# Altium schematic sheet FPGA_MISC.SchDoc(FPGA_MISC)
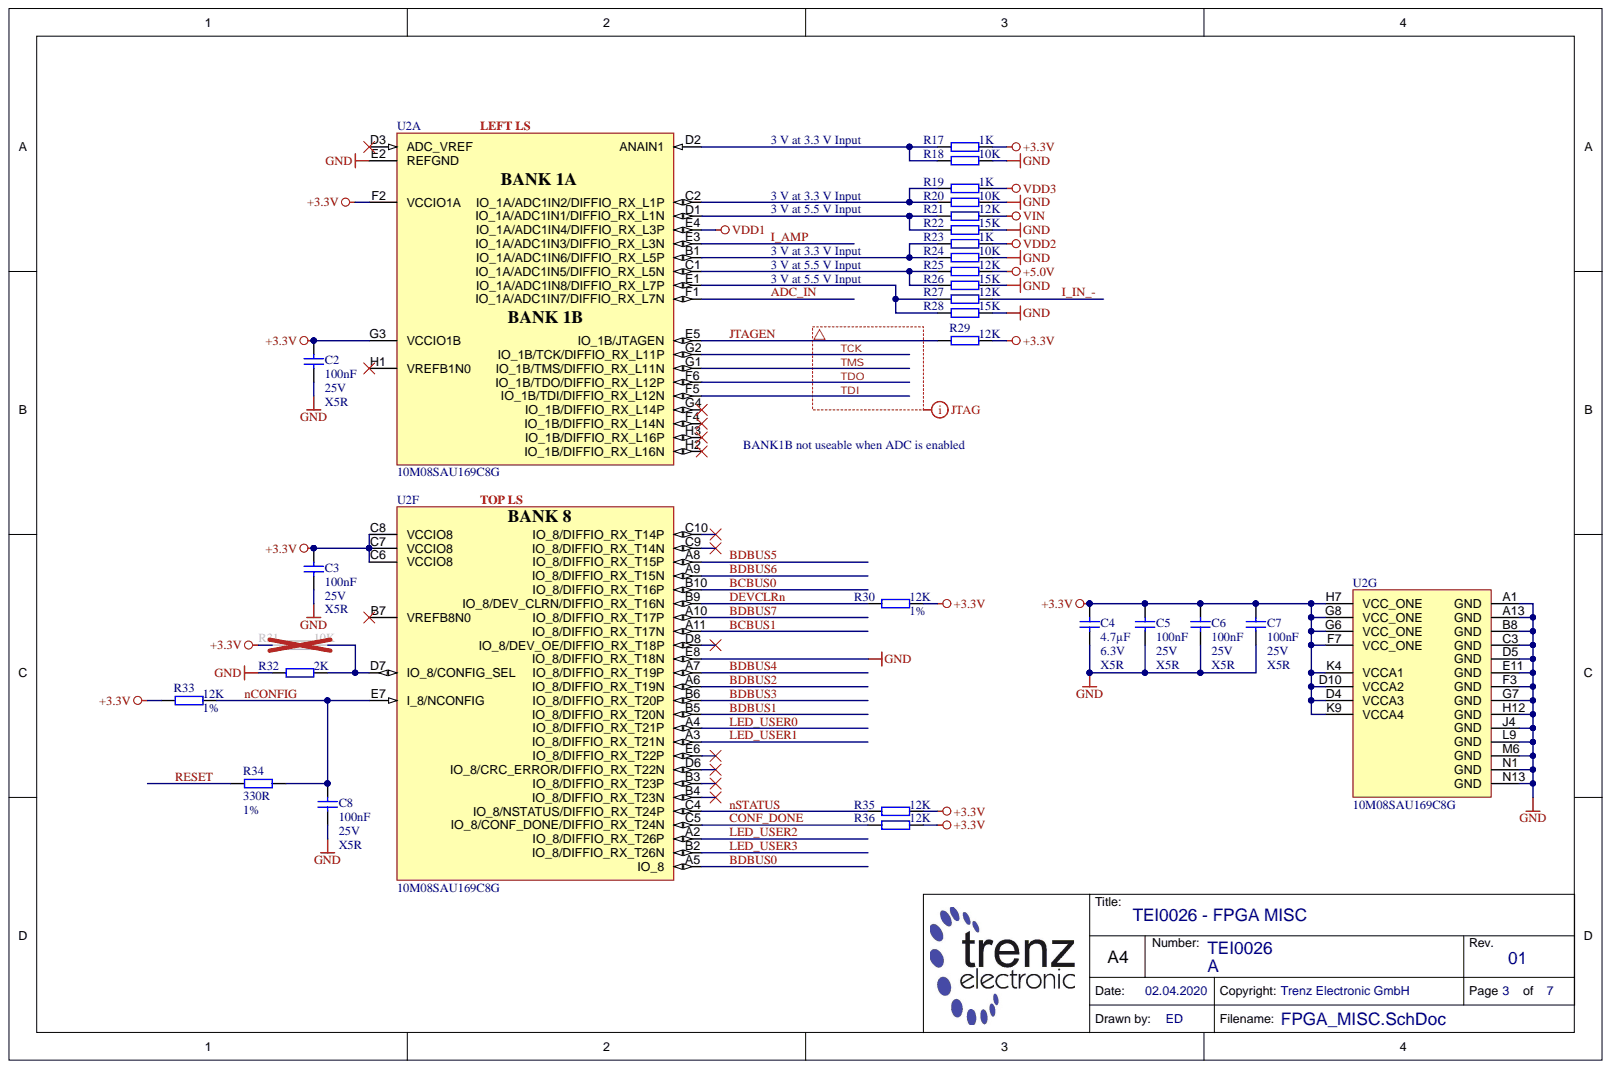
[diagram p0: FPGA_MISC.SchDoc]

## Parts
C2  100nF  Voltage: 25V  Technology: X5R  [CAPC1005X55N]
C3  100nF  Voltage: 25V  Technology: X5R  [CAPC1005X55N]
C4  4.7µF  Voltage: 6.3V  Technology: X5R  [CAPC1005X60N]
C5  100nF  Voltage: 25V  Technology: X5R  [CAPC1005X55N]
C6  100nF  Voltage: 25V  Technology: X5R  [CAPC1005X55N]
C7  100nF  Voltage: 25V  Technology: X5R  [CAPC1005X55N]
C8  100nF  Voltage: 25V  [CAPC1005X55N]
R17  1K  [RESC1005X40N]
R18  10K  [RESC1005X40N]
R19  1K  [RESC1005X40N]
R20  10K  [RESC1005X40N]
R21  12K  [RESC1005X40N]
R22  15K  [RESC1005X40N]
R23  1K  [RESC1005X40N]
R24  10K  [RESC1005X40N]
R25  12K  [RESC1005X40N]
R26  15K  [RESC1005X40N]
R27  12K  [RESC1005X40N]
R28  15K  [RESC1005X40N]
R29  12K  [RESC1005X40N]
R30  12K  Tolerance: 1%  [RESC1005X40N]
R31  10K  [RESC1005X40N]
R32  2K  [RESC1005X40N]
R33  12K  Tolerance: 1%  [RESC1005X40N]
R34  330R  Tolerance: 1%  [RESC1005X40N]
R35  12K  [RESC1005X40N]
R36  12K  [RESC1005X40N]
U2  10M08SAU169C8G  [BGA169C80P13X13_11X11X15]  (parts A,F,G)

## Nets
+3.3V: C2.1 C3.1 C4.1 C5.1 C6.1 C7.1 R17.2 R29.2 R30.2 R31.1 R33.1 R35.2 R36.2 U2.C6(VCCIO8) U2.C7(VCCIO8) U2.C8(VCCIO8) U2.D4(VCCA3) U2.D10(VCCA2) U2.F2(VCCIO1A) U2.F7(VCC_ONE) U2.G3(VCCIO1B) U2.G6(VCC_ONE) U2.G8(VCC_ONE) U2.H7(VCC_ONE) U2.K4(VCCA1) U2.K9(VCCA4)
+5.0V: R25.2
GND: C2.2 C3.2 C4.2 C5.2 C6.2 C7.2 C8.2 R18.2 R20.2 R22.2 R24.2 R26.2 R28.2 R32.2 U2.A1(GND) U2.A13(GND) U2.B8(GND) U2.C3(GND) U2.D5(GND) U2.E2(REFGND) U2.E8(IO_8/DIFFIO_RX_T18N) U2.E11(GND) U2.F3(GND) U2.G7(GND) U2.H12(GND) U2.J4(GND) U2.L9(GND) U2.M6(GND) U2.N1(GND) U2.N13(GND)
VDD1: U2.E4(IO_1A/ADC1IN4/DIFFIO_RX_L3P)
VDD2: R23.2
VDD3: R19.2
VIN: R21.2
ADC_IN: U2.F1(IO_1A/ADC1IN7/DIFFIO_RX_L7N)
BCBUS0: U2.B10(IO_8/DIFFIO_RX_T16P)
BCBUS1: U2.A11(IO_8/DIFFIO_RX_T17N)
BDBUS0: U2.A5(IO_8)
BDBUS1: U2.B5(IO_8/DIFFIO_RX_T20N)
BDBUS2: U2.A6(IO_8/DIFFIO_RX_T19N)
BDBUS3: U2.B6(IO_8/DIFFIO_RX_T20P)
BDBUS4: U2.A7(IO_8/DIFFIO_RX_T19P)
BDBUS5: U2.A8(IO_8/DIFFIO_RX_T15P)
BDBUS6: U2.A9(IO_8/DIFFIO_RX_T15N)
BDBUS7: U2.A10(IO_8/DIFFIO_RX_T17P)
CONF_DONE: R36.1 U2.C5(IO_8/CONF_DONE/DIFFIO_RX_T24N)
DEVCLRn: R30.1 U2.B9(IO_8/DEV_CLRN/DIFFIO_RX_T16N)
I_AMP: U2.E3(IO_1A/ADC1IN3/DIFFIO_RX_L3N)
I_IN_-: R27.2
JTAGEN: R29.1 U2.E5(IO_1B/JTAGEN)
LED_USER0: U2.A4(IO_8/DIFFIO_RX_T21P)
LED_USER1: U2.A3(IO_8/DIFFIO_RX_T21N)
LED_USER2: U2.A2(IO_8/DIFFIO_RX_T26P)
LED_USER3: U2.B2(IO_8/DIFFIO_RX_T26N)
nCONFIG: C8.1 R33.2 R34.2 U2.E7(I_8/NCONFIG)
nSTATUS: R35.1 U2.C4(IO_8/NSTATUS/DIFFIO_RX_T24P)
RESET: R34.1
TCK: U2.G2(IO_1B/TCK/DIFFIO_RX_L11P)
TDI: U2.F5(IO_1B/TDI/DIFFIO_RX_L12N)
TDO: U2.F6(IO_1B/TDO/DIFFIO_RX_L12P)
TMS: U2.G1(IO_1B/TMS/DIFFIO_RX_L11N)
NetR17_1: R17.1 R18.1 U2.D2(ANAIN1)
NetR19_1: R19.1 R20.1 U2.C2(IO_1A/ADC1IN2/DIFFIO_RX_L1P)
NetR21_1: R21.1 R22.1 U2.D1(IO_1A/ADC1IN1/DIFFIO_RX_L1N)
NetR23_1: R23.1 R24.1 U2.B1(IO_1A/ADC1IN6/DIFFIO_RX_L5P)
NetR25_1: R25.1 R26.1 U2.C1(IO_1A/ADC1IN5/DIFFIO_RX_L5N)
NetR27_1: R27.1 R28.1 U2.E1(IO_1A/ADC1IN8/DIFFIO_RX_L7P)
NetR31_2: R31.2 R32.1 U2.D7(IO_8/CONFIG_SEL)
N00154:

Not connected: U2 B3(IO_8/DIFFIO_RX_T23P) B4(IO_8/DIFFIO_RX_T23N) B7(VREFB8N0) C9(IO_8/DIFFIO_RX_T14N) C10(IO_8/DIFFIO_RX_T14P) D3(ADC_VREF) D6(IO_8/CRC_ERROR/DIFFIO_RX_T22N) D8(IO_8/DEV_OE/DIFFIO_RX_T18P) E6(IO_8/DIFFIO_RX_T22P) F4(IO_1B/DIFFIO_RX_L14N) G4(IO_1B/DIFFIO_RX_L14P) H1(VREFB1N0) H2(IO_1B/DIFFIO_RX_L16N) H3(IO_1B/DIFFIO_RX_L16P)

## Library data of the parts
C2 C3 C5-C8 = 22472: KON 100nF 25V X5R ±10% SMD BF 1005 (0402); Value: 100n; Temperature-Range: -55/+85; Preferred: Yes; Mixed: SMT; Database Table Name: C_1005_0402; Library Name: TE.DbLib; Component Kind: Standard; Case: 1005 (0402); AN: 22472
  C8: Technology: X5R
C4 = 24719: KON 4.7µF 6.3V X5R 20% SMD BF 1005 (0402); Value: 4.7u; Temperature-Range: -55/+85; Preferred: Yes; Mixed: SMT; Database Table Name: C_1005_0402; Tolerance: 20%; Library Name: TE.DbLib; Component Kind: Standard; Case: 1005 (0402); AN: 24719
R17 R19 R23 = 22752: WID SMD 1K 1% 0,063W  BF 1005 (0402); Preferred: Yes; Mixed: SMT; H-ART: RC0402FR-071KL; Database Table Name: R_1005_0402; Value: 1K; Tolerance: 1%; Technology: TK100; Library Name: TE.DbLib; Component Kind: Standard; Case: 1005 (0402); AN: 22752
R18 R20 R24 R31 = 22750: WID SMD 10K 1% 0,063W BF 1005 (0402); Preferred: Yes; Mixed: SMT; H-ART: RC0402FR-0710KL; Database Table Name: R_1005_0402; Value: 10K; Tolerance: 1%; Technology: TK100; Library Name: TE.DbLib; Component Kind: Standard; Case: 1005 (0402); AN: 22750
R21 R25 R27 R29 R30 R33 R35 R36 = 22598: WID SMD 12K 1% 0,063W  BF 1005 (0402); Preferred: Yes; Mixed: SMT; Database Table Name: R_1005_0402; Value: 12K; Technology: TK100; Library Name: TE.DbLib; Component Kind: Standard; Case: 1005 (0402); AN: 22598
  R21 R25 R27 R29 R35 R36: Tolerance: 1%
R22 R26 R28 = 24870: WID SMD 15K 1% 0,063W BF 1005 (0402); Preferred: Yes; Mixed: SMT; Database Table Name: R_1005_0402; Value: 15K; Tolerance: 1%; Technology: TK100; Library Name: TE.DbLib; Component Kind: Standard; Case: 1005 (0402); AN: 24870
R32 = 25073: WID SMD 2K 1% 0,063W  BF 1005 (0402); Preferred: Yes; Mixed: SMT; H-ART: RC0402FR-072KL; Database Table Name: R_1005_0402; Value: 2K; Tolerance: 1%; Technology: TK100; Library Name: TE.DbLib; Component Kind: Standard; Case: 1005 (0402); AN: 25073
R34 = 22762: WID SMD 330R 1% 0,063W  BF 1005 (0402); Value: 330; Preferred: Yes; Mixed: SMT; H-ART: RC0402FR-13330RL; Database Table Name: R_1005_0402; Technology: TK100; Library Name: TE.DbLib; Component Kind: Standard; Case: 1005 (0402); AN: 22762
U2 = 27930: Temperature-Range: 0/+85 (Tj); Mixed: SMT; Database Table Name: PROG_LOGIC; Storage-Temperature: -65/+150(Abs); Library Name: TE.DbLib; H-ART: 10M08SAU169C8G; Description: 10M08SAU169C8G; Component Kind: Standard; Case: LFBGA169; AN: 27930; HelpURL: b:\AD\adlib\datasheet\ALTERA_MAX10\
